# Altium schematic sheet DASH.SchDoc (continued)

## Library data of the parts
C1 C3 C200 = CONDENSADOR 100nF: CAP TANT 100nF 20V 10% 1206; Manufacturer 1: Kyocera AVX; Capacitance: 1uF; Dielectric Material: Tantalum; Dissipation Factor: 4%; ESR (Equivalent Series Resistance): 9R; Leakage Current: 500nA; Polarity: Polar; Tolerance: 20%; Case/Package: 1206; Case Code (Imperial): 1206; Case Code (Metric): 3216; Category: condensador; Component Kind: Standard; ComponentLink1Description: https://datasheet.ciiva.com/12883/taj-27497-12883280.pdf?src-supplier=Mouser; ComponentLink1URL: https://datasheet.ciiva.com/12883/taj-27497-12883280.pdf?src-supplier=Mouser; ComponentLink2Description: https://datasheet.ciiva.com/11794/0900766b80ccdc61-11794382.pdf?src-supplier=RSComponents; ComponentLink2URL: https://datasheet.ciiva.com/11794/0900766b80ccdc61-11794382.pdf?src-supplier=RSComponents; ComponentLink3Description: https://datasheet.ciiva.com/263/taj-263412.pdf?src-supplier=Digi-Key; ComponentLink3URL: https://datasheet.ciiva.com/263/taj-263412.pdf?src-supplier=Digi-Key; ComponentLink4Description: https://datasheet.ciiva.com/3004/taj-3004372.pdf?src-supplier=Avnet; ComponentLink4URL: https://datasheet.ciiva.com/3004/taj-3004372.pdf?src-supplier=Avnet; ComponentLink5Description: https://datasheet.ciiva.com/263/taj-263414.pdf?src-supplier=Digi-Key; ComponentLink5URL: https://datasheet.ciiva.com/263/taj-263414.pdf?src-supplier=Digi-Key; ComponentLink6Description: https://datasheet.ciiva.com/263/taj-263413.pdf?src-supplier=Digi-Key; ComponentLink6URL: https://datasheet.ciiva.com/263/taj-263413.pdf?src-supplier=Digi-Key; ComponentLink7Description: https://datasheet.ciiva.com/3004/taj-3004373.pdf?src-supplier=Avnet; ComponentLink7URL: https://datasheet.ciiva.com/3004/taj-3004373.pdf?src-supplier=Avnet; ComponentLink8Description: https://datasheet.ciiva.com/14608/70001050-14608053.pdf?src-supplier=Allied+Electronics; ComponentLink8URL: https://datasheet.ciiva.com/14608/70001050-14608053.pdf?src-supplier=Allied+Electronics; ComponentLink9Description: https://datasheet.ciiva.com/14598/70001050-14598921.pdf?src-supplier=Allied+Electronics; ComponentLink9URL: https://datasheet.ciiva.com/14598/70001050-14598921.pdf?src-supplier=Allied+Electronics; ComponentLink10Description: https://datasheet.ciiva.com/16486/taj-16486073.pdf?src-supplier=Avnet+Asia; ComponentLink10URL: https://datasheet.ciiva.com/16486/taj-16486073.pdf?src-supplier=Avnet+Asia; ComponentLink11Description: https://datasheet.ciiva.com/16203/taj-16203563.pdf?src-supplier=Future+Electronics; ComponentLink11URL: https://datasheet.ciiva.com/16203/taj-16203563.pdf?src-supplier=Future+Electronics; Depth: 1.6mm; Features: General Purpose; Height: 1.6mm; Height - Seated (Max): 0.071inch; Lead Free: Lead Free; Length: 3.2mm; Library Name: DASH1_Schlib1.SchLib; Manufacturer Part Number 1: TAJA105K020RNJ; Max Operating Temperature: 125°C; Min Operating Temperature: -55°C; Mount: Surface Mount,PCB; Number of Pins: 2; Package Quantity: 1; Packaging: Tape and Reel; Pricing 1: 1=0,31000, 10=0,21900, 100=0,13110, 500=0,09832, 1000=0,08303 (USD); Radiation Hardening: No; REACH SVHC: No SVHC; RoHS: Yes; Stock 1: 73338; Supplier 1: Digi-Key; Supplier Part Number 1: 478-1650-1-ND; Termination: SMD/SMT; Voltage: 20V; Voltage Rating: 20V; Voltage Rating (DC): 20V; Width: 1.6mm
C4 C5 = CONDENSADOR 10uF: CAP TANT 10uF 20V 10% 1206; Manufacturer 1: Kyocera AVX; Capacitance: 1uF; Dielectric Material: Tantalum; Dissipation Factor: 4%; ESR (Equivalent Series Resistance): 9R; Leakage Current: 500nA; Polarity: Polar; Tolerance: 20%; Case/Package: 1206; Case Code (Imperial): 1206; Case Code (Metric): 3216; Category: condensador; Component Kind: Standard; ComponentLink1Description: https://datasheet.ciiva.com/12883/taj-27497-12883280.pdf?src-supplier=Mouser; ComponentLink1URL: https://datasheet.ciiva.com/12883/taj-27497-12883280.pdf?src-supplier=Mouser; ComponentLink2Description: https://datasheet.ciiva.com/11794/0900766b80ccdc61-11794382.pdf?src-supplier=RSComponents; ComponentLink2URL: https://datasheet.ciiva.com/11794/0900766b80ccdc61-11794382.pdf?src-supplier=RSComponents; ComponentLink3Description: https://datasheet.ciiva.com/263/taj-263412.pdf?src-supplier=Digi-Key; ComponentLink3URL: https://datasheet.ciiva.com/263/taj-263412.pdf?src-supplier=Digi-Key; ComponentLink4Description: https://datasheet.ciiva.com/3004/taj-3004372.pdf?src-supplier=Avnet; ComponentLink4URL: https://datasheet.ciiva.com/3004/taj-3004372.pdf?src-supplier=Avnet; ComponentLink5Description: https://datasheet.ciiva.com/263/taj-263414.pdf?src-supplier=Digi-Key; ComponentLink5URL: https://datasheet.ciiva.com/263/taj-263414.pdf?src-supplier=Digi-Key; ComponentLink6Description: https://datasheet.ciiva.com/263/taj-263413.pdf?src-supplier=Digi-Key; ComponentLink6URL: https://datasheet.ciiva.com/263/taj-263413.pdf?src-supplier=Digi-Key; ComponentLink7Description: https://datasheet.ciiva.com/3004/taj-3004373.pdf?src-supplier=Avnet; ComponentLink7URL: https://datasheet.ciiva.com/3004/taj-3004373.pdf?src-supplier=Avnet; ComponentLink8Description: https://datasheet.ciiva.com/14608/70001050-14608053.pdf?src-supplier=Allied+Electronics; ComponentLink8URL: https://datasheet.ciiva.com/14608/70001050-14608053.pdf?src-supplier=Allied+Electronics; ComponentLink9Description: https://datasheet.ciiva.com/14598/70001050-14598921.pdf?src-supplier=Allied+Electronics; ComponentLink9URL: https://datasheet.ciiva.com/14598/70001050-14598921.pdf?src-supplier=Allied+Electronics; ComponentLink10Description: https://datasheet.ciiva.com/16486/taj-16486073.pdf?src-supplier=Avnet+Asia; ComponentLink10URL: https://datasheet.ciiva.com/16486/taj-16486073.pdf?src-supplier=Avnet+Asia; ComponentLink11Description: https://datasheet.ciiva.com/16203/taj-16203563.pdf?src-supplier=Future+Electronics; ComponentLink11URL: https://datasheet.ciiva.com/16203/taj-16203563.pdf?src-supplier=Future+Electronics; Depth: 1.6mm; Features: General Purpose; Height: 1.6mm; Height - Seated (Max): 0.071inch; Lead Free: Lead Free; Length: 3.2mm; Library Name: DASH1_Schlib1.SchLib; Manufacturer Part Number 1: TAJA105K020RNJ; Max Operating Temperature: 125°C; Min Operating Temperature: -55°C; Mount: Surface Mount,PCB; Number of Pins: 2; Package Quantity: 1; Packaging: Tape and Reel; Pricing 1: 1=0,31000, 10=0,21900, 100=0,13110, 500=0,09832, 1000=0,08303 (USD); Radiation Hardening: No; REACH SVHC: No SVHC; RoHS: Yes; Stock 1: 73338; Supplier 1: Digi-Key; Supplier Part Number 1: 478-1650-1-ND; Termination: SMD/SMT; Voltage: 20V; Voltage Rating: 20V; Voltage Rating (DC): 20V; Width: 1.6mm
C6-C14 = CONDENSADOR 1uF: CAP TANT 1uF 20V 10% 1206; Manufacturer 1: Kyocera AVX; Dielectric Material: Tantalum; Dissipation Factor: 4%; ESR (Equivalent Series Resistance): 9R; Leakage Current: 500nA; Polarity: Polar; Tolerance: 20%; Capacitance: 1uF; Case/Package: 1206; Case Code (Imperial): 1206; Case Code (Metric): 3216; Category: condensador; Component Kind: Standard; ComponentLink1Description: https://datasheet.ciiva.com/12883/taj-27497-12883280.pdf?src-supplier=Mouser; ComponentLink1URL: https://datasheet.ciiva.com/12883/taj-27497-12883280.pdf?src-supplier=Mouser; ComponentLink2Description: https://datasheet.ciiva.com/11794/0900766b80ccdc61-11794382.pdf?src-supplier=RSComponents; ComponentLink2URL: https://datasheet.ciiva.com/11794/0900766b80ccdc61-11794382.pdf?src-supplier=RSComponents; ComponentLink3Description: https://datasheet.ciiva.com/263/taj-263412.pdf?src-supplier=Digi-Key; ComponentLink3URL: https://datasheet.ciiva.com/263/taj-263412.pdf?src-supplier=Digi-Key; ComponentLink4Description: https://datasheet.ciiva.com/3004/taj-3004372.pdf?src-supplier=Avnet; ComponentLink4URL: https://datasheet.ciiva.com/3004/taj-3004372.pdf?src-supplier=Avnet; ComponentLink5Description: https://datasheet.ciiva.com/263/taj-263414.pdf?src-supplier=Digi-Key; ComponentLink5URL: https://datasheet.ciiva.com/263/taj-263414.pdf?src-supplier=Digi-Key; ComponentLink6Description: https://datasheet.ciiva.com/263/taj-263413.pdf?src-supplier=Digi-Key; ComponentLink6URL: https://datasheet.ciiva.com/263/taj-263413.pdf?src-supplier=Digi-Key; ComponentLink7Description: https://datasheet.ciiva.com/3004/taj-3004373.pdf?src-supplier=Avnet; ComponentLink7URL: https://datasheet.ciiva.com/3004/taj-3004373.pdf?src-supplier=Avnet; ComponentLink8Description: https://datasheet.ciiva.com/14608/70001050-14608053.pdf?src-supplier=Allied+Electronics; ComponentLink8URL: https://datasheet.ciiva.com/14608/70001050-14608053.pdf?src-supplier=Allied+Electronics; ComponentLink9Description: https://datasheet.ciiva.com/14598/70001050-14598921.pdf?src-supplier=Allied+Electronics; ComponentLink9URL: https://datasheet.ciiva.com/14598/70001050-14598921.pdf?src-supplier=Allied+Electronics; ComponentLink10Description: https://datasheet.ciiva.com/16486/taj-16486073.pdf?src-supplier=Avnet+Asia; ComponentLink10URL: https://datasheet.ciiva.com/16486/taj-16486073.pdf?src-supplier=Avnet+Asia; ComponentLink11Description: https://datasheet.ciiva.com/16203/taj-16203563.pdf?src-supplier=Future+Electronics; ComponentLink11URL: https://datasheet.ciiva.com/16203/taj-16203563.pdf?src-supplier=Future+Electronics; Depth: 1.6mm; Features: General Purpose; Height: 1.6mm; Height - Seated (Max): 0.071inch; Lead Free: Lead Free; Length: 3.2mm; Library Name: DASH1_Schlib1.SchLib; Manufacturer Part Number 1: TAJA105K020RNJ; Max Operating Temperature: 125°C; Min Operating Temperature: -55°C; Mount: Surface Mount,PCB; Number of Pins: 2; Package Quantity: 1; Packaging: Tape and Reel; Pricing 1: 1=0,31000, 10=0,21900, 100=0,13110, 500=0,09832, 1000=0,08303 (USD); Radiation Hardening: No; REACH SVHC: No SVHC; RoHS: Yes; Stock 1: 73338; Supplier 1: Digi-Key; Supplier Part Number 1: 478-1650-1-ND; Termination: SMD/SMT; Voltage: 20V; Voltage Rating: 20V; Voltage Rating (DC): 20V; Width: 1.6mm
C15b C16 = CONDENSADOR 15pF: CAP TANT 15pF 20V 10% 1206; Manufacturer 1: Kyocera AVX; Capacitance: 1uF; Dielectric Material: Tantalum; Dissipation Factor: 4%; ESR (Equivalent Series Resistance): 9R; Leakage Current: 500nA; Polarity: Polar; Tolerance: 20%; Case/Package: 1206; Case Code (Imperial): 1206; Case Code (Metric): 3216; Category: condensador; Component Kind: Standard; ComponentLink1Description: https://datasheet.ciiva.com/12883/taj-27497-12883280.pdf?src-supplier=Mouser; ComponentLink1URL: https://datasheet.ciiva.com/12883/taj-27497-12883280.pdf?src-supplier=Mouser; ComponentLink2Description: https://datasheet.ciiva.com/11794/0900766b80ccdc61-11794382.pdf?src-supplier=RSComponents; ComponentLink2URL: https://datasheet.ciiva.com/11794/0900766b80ccdc61-11794382.pdf?src-supplier=RSComponents; ComponentLink3Description: https://datasheet.ciiva.com/263/taj-263412.pdf?src-supplier=Digi-Key; ComponentLink3URL: https://datasheet.ciiva.com/263/taj-263412.pdf?src-supplier=Digi-Key; ComponentLink4Description: https://datasheet.ciiva.com/3004/taj-3004372.pdf?src-supplier=Avnet; ComponentLink4URL: https://datasheet.ciiva.com/3004/taj-3004372.pdf?src-supplier=Avnet; ComponentLink5Description: https://datasheet.ciiva.com/263/taj-263414.pdf?src-supplier=Digi-Key; ComponentLink5URL: https://datasheet.ciiva.com/263/taj-263414.pdf?src-supplier=Digi-Key; ComponentLink6Description: https://datasheet.ciiva.com/263/taj-263413.pdf?src-supplier=Digi-Key; ComponentLink6URL: https://datasheet.ciiva.com/263/taj-263413.pdf?src-supplier=Digi-Key; ComponentLink7Description: https://datasheet.ciiva.com/3004/taj-3004373.pdf?src-supplier=Avnet; ComponentLink7URL: https://datasheet.ciiva.com/3004/taj-3004373.pdf?src-supplier=Avnet; ComponentLink8Description: https://datasheet.ciiva.com/14608/70001050-14608053.pdf?src-supplier=Allied+Electronics; ComponentLink8URL: https://datasheet.ciiva.com/14608/70001050-14608053.pdf?src-supplier=Allied+Electronics; ComponentLink9Description: https://datasheet.ciiva.com/14598/70001050-14598921.pdf?src-supplier=Allied+Electronics; ComponentLink9URL: https://datasheet.ciiva.com/14598/70001050-14598921.pdf?src-supplier=Allied+Electronics; ComponentLink10Description: https://datasheet.ciiva.com/16486/taj-16486073.pdf?src-supplier=Avnet+Asia; ComponentLink10URL: https://datasheet.ciiva.com/16486/taj-16486073.pdf?src-supplier=Avnet+Asia; ComponentLink11Description: https://datasheet.ciiva.com/16203/taj-16203563.pdf?src-supplier=Future+Electronics; ComponentLink11URL: https://datasheet.ciiva.com/16203/taj-16203563.pdf?src-supplier=Future+Electronics; Depth: 1.6mm; Features: General Purpose; Height: 1.6mm; Height - Seated (Max): 0.071inch; Lead Free: Lead Free; Length: 3.2mm; Library Name: DASH1_Schlib1.SchLib; Manufacturer Part Number 1: TAJA105K020RNJ; Max Operating Temperature: 125°C; Min Operating Temperature: -55°C; Mount: Surface Mount,PCB; Number of Pins: 2; Package Quantity: 1; Packaging: Tape and Reel; Pricing 1: 1=0,31000, 10=0,21900, 100=0,13110, 500=0,09832, 1000=0,08303 (USD); Radiation Hardening: No; REACH SVHC: No SVHC; RoHS: Yes; Stock 1: 73338; Supplier 1: Digi-Key; Supplier Part Number 1: 478-1650-1-ND; Termination: SMD/SMT; Voltage: 20V; Voltage Rating: 20V; Voltage Rating (DC): 20V; Width: 1.6mm
D D15-D55 = LED_SEG: LED GREEN CLEAR 2SMD 528NM; Manufacturer 1: Wurth Electronics; Component Kind: Standard; ComponentLink1Description: https://katalog.we-online.de/led/datasheet/150224GS73100.pdf?src-supplier=Digi-Key; ComponentLink1URL: https://katalog.we-online.de/led/datasheet/150224GS73100.pdf?src-supplier=Digi-Key; Library Name: DASH1_Schlib1.SchLib; Manufacturer Part Number 1: 150224GS73100; Pricing 1: 1=0,71000, 50=0,58400, 100=0,41800, 500=0,38100 (USD); RoHS: No; Stock 1: 697; Supplier 1: Digi-Key; Supplier Part Number 1: 732-11398-1-ND
D1 D2 = DIODE: TVS DIODE 12V 19.9V DO214AC; Manufacturer 1: Littelfuse; Breakdown Voltage: 13.3V; Capacitance: 800pF; Direction: Bidirectional; Element Configuration: Single; Leakage Current: 1uA; Max Breakdown Voltage: 14.7V; Max Output Current: 20.1A; Max Power Dissipation: 3.3W; Number of Bidirectional Channels: 1; Peak Pulse Power: 400W; Power Line Protection: No; Test Current: 1mA; Case/Package: SMA; Category: Diode; Clamping Voltage: 19.9V; Component Kind: Standard; ComponentLink1Description: https://datasheet.ciiva.com/14162/smaj-eng-ds-14162034.pdf?src-supplier=Distrelec; ComponentLink1URL: https://datasheet.ciiva.com/14162/smaj-eng-ds-14162034.pdf?src-supplier=Distrelec; ComponentLink2Description: https://datasheet.ciiva.com/25624/0900766b810f4edb-25624831.pdf?src-supplier=RSComponents; ComponentLink2URL: https://datasheet.ciiva.com/25624/0900766b810f4edb-25624831.pdf?src-supplier=RSComponents; ComponentLink3Description: https://datasheet.ciiva.com/331/littelfuse-tvs-diode-smaj-datasheet-pdf-331080.pdf?src-supplier=Digi-Key; ComponentLink3URL: https://datasheet.ciiva.com/331/littelfuse-tvs-diode-smaj-datasheet-pdf-331080.pdf?src-supplier=Digi-Key; ComponentLink4Description: https://datasheet.ciiva.com/8062/littelfuse-tvs-diode-smaj-8062265.pdf?src-supplier=Element14; ComponentLink4URL: https://datasheet.ciiva.com/8062/littelfuse-tvs-diode-smaj-8062265.pdf?src-supplier=Element14; ComponentLink5Description: https://datasheet.ciiva.com/4511/littelfuse-tvs-diode-smaj-23503-4511037.pdf?src-supplier=Mouser; ComponentLink5URL: https://datasheet.ciiva.com/4511/littelfuse-tvs-diode-smaj-23503-4511037.pdf?src-supplier=Mouser; ComponentLink6Description: https://datasheet.ciiva.com/28473/607617-28473381.pdf?src-supplier=Element14; ComponentLink6URL: https://datasheet.ciiva.com/28473/607617-28473381.pdf?src-supplier=Element14; ComponentLink7Description: https://datasheet.ciiva.com/331/littelfuse-tvs-diode-smaj-datasheet-pdf-331078.pdf?src-supplier=Digi-Key; ComponentLink7URL: https://datasheet.ciiva.com/331/littelfuse-tvs-diode-smaj-datasheet-pdf-331078.pdf?src-supplier=Digi-Key; ComponentLink8Description: https://datasheet.ciiva.com/331/littelfuse-tvs-diode-smaj-datasheet-pdf-331079.pdf?src-supplier=Digi-Key; ComponentLink8URL: https://datasheet.ciiva.com/331/littelfuse-tvs-diode-smaj-datasheet-pdf-331079.pdf?src-supplier=Digi-Key; Composition: Zener; Height: 2.087mm; Lead Free: Lead Free; Length: 4.5mm; Library Name: DASH1_Schlib1.SchLib; Manufacturer Part Number 1: SMAJ12CA; Max Operating Temperature: 150°C; Max Reverse Leakage Current: 1uA; Max Surge Current: 20.1A; Min Breakdown Voltage: 13.3V; Min Operating Temperature: -65°C; Mount: Surface Mount; Number of Channels: 1; Number of Elements: 1; Number of Pins: 2; Operating Supply Voltage: 12V; Package Quantity: 5000; Packaging: Tape and Reel; Peak Pulse Current: 20.1A; Polarity: Bidirectional; Power Dissipation: 400W; Pricing 1: 1=0,45000, 10=0,33900, 25=0,30520, 100=0,16100, 250=0,15928, 500=0,14264, 1000=0,11100, 2500=0,10156 (USD); Radiation Hardening: No; REACH SVHC: No SVHC; Reverse Breakdown Voltage: 13.3V; Reverse Standoff Voltage: 12V; RoHS: Yes; Stock 1: 18116; Supplier 1: Digi-Key; Supplier Part Number 1: SMAJ12CALFCT-ND; Termination: SMD/SMT; Voltage Rating (DC): 12V; Width: 2.79mm
D3-D14 = LED: WURTH ELEKTRONIK   150141GS73100   LED, 1411, GREEN, 1000MCD, 525NM; Manufacturer 1: Wurth Electronics; Forward Current: 30mA; Forward Voltage: 3.1V; Lens Style: Clear,Round; Test Current: 20mA; Viewing Angle: 120°; Case/Package: 3528; Case Code (Imperial): 1411; Case Code (Metric): 3528; Color: Green; Component Kind: Standard; ComponentLink1Description: https://datasheet.ciiva.com/23843/150141gs73100-23843266.pdf?src-supplier=Newark; ComponentLink1URL: https://datasheet.ciiva.com/23843/150141gs73100-23843266.pdf?src-supplier=Newark; ComponentLink2Description: https://datasheet.ciiva.com/29278/759463-da-01-en-smd-topled-einf-gruen-150141gs73100-29278953.pdf?src-supplier=Conrad; ComponentLink2URL: https://datasheet.ciiva.com/29278/759463-da-01-en-smd-topled-einf-gruen-150141gs73100-29278953.pdf?src-supplier=Conrad; ComponentLink3Description: https://datasheet.ciiva.com/22656/1911484-22656997.pdf?src-supplier=Element14; ComponentLink3URL: https://datasheet.ciiva.com/22656/1911484-22656997.pdf?src-supplier=Element14; ComponentLink4Description: https://datasheet.ciiva.com/29563/150141gs73100-29563029.pdf?src-supplier=Digi-Key; ComponentLink4URL: https://datasheet.ciiva.com/29563/150141gs73100-29563029.pdf?src-supplier=Digi-Key; ComponentLink5Description: https://datasheet.ciiva.com/29563/150141gs73100-29563030.pdf?src-supplier=Digi-Key; ComponentLink5URL: https://datasheet.ciiva.com/29563/150141gs73100-29563030.pdf?src-supplier=Digi-Key; ComponentLink6Description: https://datasheet.ciiva.com/48430/150141gs73100-eng-tds-48430060.pdf?src-supplier=Distrelec; ComponentLink6URL: https://datasheet.ciiva.com/48430/150141gs73100-eng-tds-48430060.pdf?src-supplier=Distrelec; Dominant Wavelength: 525nm; Height: 1.9mm; Lead Free: Lead Free; Length: 3.5mm; Library Name: DASH1_Schlib1.SchLib; Luminous Intensity: 1000mcd; Manufacturer Part Number 1: 150141GS73100; Max Operating Temperature: 85°C; Min Operating Temperature: -40°C; Mount: Surface Mount; Package Quantity: 2000; Packaging: Cut Tape; Pricing 1: 1=0,18000, 50=0,17600, 100=0,15300, 500=0,11700 (USD); REACH SVHC: Unknown; RoHS: Yes; Stock 1: 10639; Supplier 1: Digi-Key; Supplier Part Number 1: 732-4997-1-ND; Wavelength: 525nm; Width: 2.8mm
DISPLAY = DISPLAY: 2.54mm (0.1") Pitch SIL Vertical PC Tail Socket Assembly, selective gold + tin, 4 contacts; Manufacturer 1: Harwin; Connector Type: Receptacle,Socket; Contact Resistance: 20mR; Gender: Female; Max Current Rating: 3A; Number of Contacts: 4; Case/Package: SIL; Color: Black; Component Kind: Standard; ComponentLink1Description: DATASHEET; ComponentLink1URL: https://eu.mouser.com/datasheet/2/127/dogl128-6e-3984.pdf; ComponentLink2Description: WHERE BUY IT; ComponentLink2URL: https://eu.mouser.com/productdetail/electronic-assembly/ea-led68x51-w?qs=7%252buJNxjmPmV34T7aeje2sQ==; Contact Gender: Female; Contact Material: Bronze; Contact Plating: Gold,Tin; Depth: 2.5mm; Housing Color: Black; Housing Material: Nylon; Length: 10.56mm; Library Name: DASH1_Schlib1.SchLib; Manufacturer Part Number 1: M20-7820442; Max Operating Temperature: 105°C; Min Operating Temperature: -40°C; Mount: Through Hole; Number of Positions: 4; Number of Rows: 1; Orientation: Straight; Package Quantity: 56; Packaging: Rail/Tube; Pitch: 2.54mm; Pricing 1: 1=0,81000, 10=0,71400, 100=0,61600, 500=0,50400, 1000=0,44800, 5000=0,39200, 10000=0,37240 (USD); Radiation Hardening: No; RoHS: Yes; Stock 1: 903; Supplier 1: Digi-Key; Supplier Part Number 1: 952-1791-ND; Termination: Solder; Weight: 0.012699oz
J2 J3 J8 = HEADER: CONN HEADER VERT 2POS 2.54MM; Manufacturer 1: Amphenol FCI; Connector Type: Male Pin; Contact Post Length: 3.05mm; Current Rating: 3A; Gender: Male; Insulation Resistance: 5GR; Max Voltage Rating (AC): 1.5kV; Number of Contacts: 2; Category: Connector; Color: Black; Component Kind: Standard; ComponentLink1Description: https://datasheet.ciiva.com/5155/68000-274330-5155060.pdf?src-supplier=Mouser; ComponentLink1URL: https://datasheet.ciiva.com/5155/68000-274330-5155060.pdf?src-supplier=Mouser; ComponentLink2Description: https://datasheet.ciiva.com/16726/68000-16726182.pdf?src-supplier=Avnet+Europe; ComponentLink2URL: https://datasheet.ciiva.com/16726/68000-16726182.pdf?src-supplier=Avnet+Europe; ComponentLink3Description: https://datasheet.ciiva.com/10149/68000-10149336.pdf?src-supplier=Avnet; ComponentLink3URL: https://datasheet.ciiva.com/10149/68000-10149336.pdf?src-supplier=Avnet; ComponentLink4Description: https://datasheet.ciiva.com/731/68000-731070.pdf?src-supplier=Digi-Key; ComponentLink4URL: https://datasheet.ciiva.com/731/68000-731070.pdf?src-supplier=Digi-Key; Contact Gender: Male; Contact Material: Bronze; Contact Mating Length: 0.23inch; Contact Plating: Gold,GXT; Depth: 2.41mm; Flammability Rating: UL94 V-0; Housing Color: Black; Housing Material: Thermoplastic; Length: 5.08mm; Library Name: DASH1_Schlib1.SchLib; Manufacturer Part Number 1: 68001-202HLF; Max Operating Temperature: 125°C; Min Operating Temperature: -65°C; Mount: Through Hole; Number of Positions: 2; Number of Rows: 1; Orientation: Straight; Package Quantity: 100; Packaging: Bulk; Pitch: 2.54mm; Pricing 1: 1=0,24000, 10=0,22100, 100=0,15290, 500=0,12936, 1000=0,10584, 5000=0,09408, 10000=0,08526 (USD); Radiation Hardening: No; REACH SVHC: No SVHC; RoHS: Yes; Stock 1: 39971; Supplier 1: Digi-Key; Supplier Part Number 1: 609-3469-ND; Termination: Solder; Voltage Rating: 1.5kV
J4 = HEADER_J4: CONN HEADER R/A 2POS; Manufacturer 1: Molex; Approvals: UL,CSA,TUV; Contact Resistance: 10mR; Current Rating: 5A; Gender: Male; Glow Wire Compliant: Compliant; Insulation Resistance: 1GR; Max Voltage Rating (DC): 250.25kV; Number of Circuits: 2; Pitch: 3mm; Voltage Rating: 600V; Voltage Rating (DC): 250V; Case/Package: SMC; Color: Black; Component Kind: Standard; ComponentLink1Description: https://datasheet.ciiva.com/14155/04402310-14155203.pdf?src-supplier=Distrelec; ComponentLink1URL: https://datasheet.ciiva.com/14155/04402310-14155203.pdf?src-supplier=Distrelec; ComponentLink2Description: https://datasheet.ciiva.com/19454/883270-19454006.pdf?src-supplier=Jameco; ComponentLink2URL: https://datasheet.ciiva.com/19454/883270-19454006.pdf?src-supplier=Jameco; ComponentLink3Description: https://datasheet.ciiva.com/5150/0430450200-pcb-headers-145770-5150407.pdf?src-supplier=Mouser; ComponentLink3URL: https://datasheet.ciiva.com/5150/0430450200-pcb-headers-145770-5150407.pdf?src-supplier=Mouser; ComponentLink4Description: https://datasheet.ciiva.com/11747/0900766b80027348-11747001.pdf?src-supplier=RSComponents; ComponentLink4URL: https://datasheet.ciiva.com/11747/0900766b80027348-11747001.pdf?src-supplier=RSComponents; ComponentLink5Description: https://datasheet.ciiva.com/16656/0430450200-pcb-headers-16656330.pdf?src-supplier=Avnet+Europe; ComponentLink5URL: https://datasheet.ciiva.com/16656/0430450200-pcb-headers-16656330.pdf?src-supplier=Avnet+Europe; ComponentLink6Description: https://datasheet.ciiva.com/10090/0430450200-pcb-headers-10090299.pdf?src-supplier=Avnet; ComponentLink6URL: https://datasheet.ciiva.com/10090/0430450200-pcb-headers-10090299.pdf?src-supplier=Avnet; ComponentLink7Description: https://datasheet.ciiva.com/36854/124280430450200-pcb-headers-36854331.pdf?src-supplier=Onlinecomponents.com; ComponentLink7URL: https://datasheet.ciiva.com/36854/124280430450200-pcb-headers-36854331.pdf?src-supplier=Onlinecomponents.com; ComponentLink8Description: https://datasheet.ciiva.com/1443/1859921-1443437.pdf?src-supplier=Element14; ComponentLink8URL: https://datasheet.ciiva.com/1443/1859921-1443437.pdf?src-supplier=Element14; Contact Gender: Male; Contact Material: Brass,Tin; Contact Plating: Tin; Diameter: 3mm; Flammability Rating: UL94 V-0; Halogen Free: Low Halogen; Housing Color: Black; Housing Material: Thermoplastic; Lead Free: Lead Free; Library Name: DASH1_Schlib1.SchLib; Manufacturer Part Number 1: 43045-0200; Material: Thermoplastic; Max Operating Temperature: 105°C; Min Operating Temperature: -40°C; Mount: Through Hole; Number of Contacts: 2; Number of Positions: 2; Number of Rows: 2; Orientation: Right Angle; Package Quantity: 3168; Packaging: Tray; Pricing 1: 1=0,97000, 10=0,84900, 100=0,73280, 500=0,59958, 1000=0,53296, 5000=0,46634, 10000=0,44302 (USD); Radiation Hardening: No; REACH SVHC: Unknown; RoHS: Yes; Row Spacing: 3mm; Stock 1: 6359; Supplier 1: Digi-Key; Supplier Part Number 1: WM1813-ND; Termination: Through Hole
J5 = HEADER_J5: NANO-FIT RIGHT ANGLE HEADER, TH; Manufacturer 1: Molex; Connector Type: Header,Male Pin; Number of Positions: 4; Component Kind: Standard; ComponentLink1Description: https://www.molex.com/pdm_docs/ps/PS-105300-100-001.pdf?src-supplier=Digi-Key; ComponentLink1URL: https://www.molex.com/pdm_docs/ps/PS-105300-100-001.pdf?src-supplier=Digi-Key; Contact Material: Brass; Contact Plating: Tin; Contact Shape: Square; Fastening Type: Latch; Insulation Color: Black; Insulation Material: Polymer; Library Name: DASH1_Schlib1.SchLib; Manufacturer Part Number 1: 1053141104; Max Operating Temperature: 105°C; Min Operating Temperature: -40°C; Mount: Through Hole; Number of Rows: 2; Packaging: Tray; Pricing 1: 1=1,08000, 10=0,95100, 300=0,74580, 600=0,67122, 1200=0,59664, 5100=0,52206, 10200=0,49596 (USD); RoHS: No; Stock 1: 1022; Supplier 1: Digi-Key; Supplier Part Number 1: WM16907-ND; Termination: Solder
J6 = HEADER_J6: CONN HEADER R/A 8POS 3MM; Manufacturer 1: Molex; Approvals: UL,CSA,TUV; Contact Resistance: 10mR; Current Rating: 5A; Gender: Male; Glow Wire Compliant: Compliant; Insulation Resistance: 1GR; Max Voltage Rating (DC): 250.25kV; Number of Circuits: 8; Voltage Rating: 600V; Voltage Rating (AC): 250V; Case/Package: SMC; Color: Black; Component Kind: Standard; ComponentLink1Description: https://datasheet.ciiva.com/5158/0430450800-pcb-headers-142625-5158817.pdf?src-supplier=Mouser; ComponentLink1URL: https://datasheet.ciiva.com/5158/0430450800-pcb-headers-142625-5158817.pdf?src-supplier=Mouser; ComponentLink2Description: https://datasheet.ciiva.com/7683/27645-7683683.pdf?src-supplier=Element14; ComponentLink2URL: https://datasheet.ciiva.com/7683/27645-7683683.pdf?src-supplier=Element14; ComponentLink3Description: https://datasheet.ciiva.com/19462/883536-19462164.pdf?src-supplier=Jameco; ComponentLink3URL: https://datasheet.ciiva.com/19462/883536-19462164.pdf?src-supplier=Jameco; ComponentLink4Description: https://datasheet.ciiva.com/11751/0900766b80027348-11751142.pdf?src-supplier=RSComponents; ComponentLink4URL: https://datasheet.ciiva.com/11751/0900766b80027348-11751142.pdf?src-supplier=RSComponents; ComponentLink5Description: https://datasheet.ciiva.com/30198/267770430450800-pcb-headers-30198875.pdf?src-supplier=Onlinecomponents.com; ComponentLink5URL: https://datasheet.ciiva.com/30198/267770430450800-pcb-headers-30198875.pdf?src-supplier=Onlinecomponents.com; ComponentLink6Description: https://datasheet.ciiva.com/1443/1850016-1443537.pdf?src-supplier=Element14; ComponentLink6URL: https://datasheet.ciiva.com/1443/1850016-1443537.pdf?src-supplier=Element14; ComponentLink7Description: https://datasheet.ciiva.com/14155/04402310-14155206.pdf?src-supplier=Distrelec; ComponentLink7URL: https://datasheet.ciiva.com/14155/04402310-14155206.pdf?src-supplier=Distrelec; ComponentLink8Description: https://datasheet.ciiva.com/16657/0430450800-pcb-headers-16657964.pdf?src-supplier=Avnet+Europe; ComponentLink8URL: https://datasheet.ciiva.com/16657/0430450800-pcb-headers-16657964.pdf?src-supplier=Avnet+Europe; ComponentLink9Description: https://datasheet.ciiva.com/10087/0430450800-pcb-headers-10087853.pdf?src-supplier=Avnet; ComponentLink9URL: https://datasheet.ciiva.com/10087/0430450800-pcb-headers-10087853.pdf?src-supplier=Avnet; Contact Material: Brass,Tin; Contact Plating: Tin; Depth: 12.2mm; Diameter: 3mm; Flammability Rating: UL94 V-0; Halogen Free: Low Halogen; Height: 8.77mm; Housing Color: Black; Housing Material: Thermoplastic; Lead Free: Lead Free; Length: 16.15mm; Library Name: DASH1_Schlib1.SchLib; Manufacturer Part Number 1: 43045-0800; Material: Polyester; Max Current Rating: 5A; Max Operating Temperature: 105°C; Min Operating Temperature: -40°C; Mount: Through Hole; Number of Contacts: 8; Number of Poles: 8; Number of Positions: 8; Number of Rows: 2; Orientation: Right Angle; Package Quantity: 1440; Packaging: Tray; Pitch: 3mm; Pricing 1: 1=1,91000, 10=1,73100, 100=1,48390, 500=1,23656, 1000=1,05990, 5000=0,93978, 10000=0,91858 (USD); Radiation Hardening: No; REACH SVHC: Unknown; RoHS: Yes; Row Spacing: 3mm; Stock 1: 1548; Supplier 1: Digi-Key; Supplier Part Number 1: WM1816-ND; Termination: Solder; Voltage Rating (DC): 250V; Working Voltage: 250V
J7 = HEADER_J7: Conn Power HDR 8 POS 2.5mm Solder RA Thru-Hole 8 Terminal 1 Port Nano-Fit Tray; Manufacturer 1: Molex; Connector Type: Header,Male Pin; Number of Positions: 8; Component Kind: Standard; ComponentLink1Description: Datasheet; ComponentLink1URL: https://www.molex.com/pdm_docs/sd/1053141108_sd.pdf?src-supplier=Digi-Key; ComponentLink2Description: INFO; ComponentLink2URL: https://www.molex.com/molex/products/part-detail/pcb_headers/1053141108; Contact Material: Brass; Contact Plating: Tin; Contact Shape: Square; Fastening Type: Latch; Insulation Color: Black; Insulation Material: Polymer; Library Name: DASH1_Schlib1.SchLib; Manufacturer Part Number 1: 105314-1108; Max Operating Temperature: 105°C; Min Operating Temperature: -40°C; Mount: Through Hole; Number of Rows: 2; Packaging: Tray; Pricing 1: 1=1,71000, 10=1,55200, 156=1,33013, 624=1,10845, 1092=0,95010, 5148=0,84242, 10140=0,82342 (USD); RoHS: No; Stock 1: 846; Supplier 1: Digi-Key; Supplier Part Number 1: WM16909-ND; Termination: Solder
J9 = HEADER_J9: CONN HEADER R/A 2POS 2.5MM; Manufacturer 1: Molex; Gender: Male; Color: Black; Component Kind: Standard; ComponentLink1Description: http://www.farnell.com/datasheets/2221462.pdf?src-supplier=Farnell; ComponentLink1URL: http://www.farnell.com/datasheets/2221462.pdf?src-supplier=Farnell; ComponentLink2Description: http://www.farnell.com/datasheets/2059602.pdf?src-supplier=Element14; ComponentLink2URL: http://www.farnell.com/datasheets/2059602.pdf?src-supplier=Element14; ComponentLink3Description: http://www.farnell.com/datasheets/2221462.pdf?src-supplier=Newark; ComponentLink3URL: http://www.farnell.com/datasheets/2221462.pdf?src-supplier=Newark; ComponentLink4Description: https://www.molex.com/pdm_docs/sd/1053131102_sd.pdf?src-supplier=Digi-Key; ComponentLink4URL: https://www.molex.com/pdm_docs/sd/1053131102_sd.pdf?src-supplier=Digi-Key; Contact Material: Brass; Contact Plating: Gold; Library Name: DASH1_Schlib1.SchLib; Manufacturer Part Number 1: 105313-1202; Mount: Through Hole; Number of Pins: 2; Number of Rows: 1; Pricing 1: 10=0,81300, 50=0,47400, 200=0,46200, 500=0,45000 (EUR); REACH SVHC: Unknown; RoHS: No; Stock 1: 130; Supplier 1: RSComponents; Supplier Part Number 1: 1225083; Termination: Through Hole
PIC18LF4685-I/PT = PIC18LF4685-I/PT: IC MCU 8BIT 96KB FLASH 44TQFP; Manufacturer 1: Microchip; Access Time: 40us; Core Architecture: PIC; Data Bus Width: 8b; Frequency: 40MHz; Interface: I2C,SPI,CAN,UART,USART; Max Power Dissipation: 1W; Max Supply Voltage: 5.5V; Min Supply Voltage: 2V; Number of ADC Channels: 11; Number of I2C Channels: 1; Number of I/Os: 36; Number of Programmable I/O: 44; Number of Timers/Counters: 4; Oscillator Type: Internal; Peripherals: PWM,I2C,Brown-out Detect/Reset,POR,WDT,HLVD; RAM Size: 3.25KB; Watchdog Timer: Yes; Case/Package: TQFP; Component Kind: Standard; ComponentLink1Description: DATASHEET; ComponentLink1URL: https://datasheet.ciiva.com/9230/jp026433-9230074.pdf?src-supplier=Avnet; Height: 1.05mm; Lead Free: Lead Free; Length: 10mm; Library Name: DASH1_Schlib1.SchLib; Manufacturer Part Number 1: PIC18LF4685-I/PT; Max Frequency: 40MHz; Max Operating Temperature: 85°C; Memory Size: 96KB; Memory Type: FLASH; Min Operating Temperature: -40°C; Mount: Surface Mount; Number of Pins: 44; Number of SPI Channels: 1; Number of UART Channels: 1; Package Quantity: 160; Packaging: Tray; Pricing 1: 1=8,79000, 25=8,06000 (USD); Radiation Hardening: No; REACH SVHC: No SVHC; RoHS: Yes; Stock 1: 53; Supplier 1: Digi-Key; Supplier Part Number 1: PIC18LF4685-I/PT-ND; Width: 10mm
Pickit_3 = HEADER_J1: Conn Unshrouded Header HDR 5 POS 2.54mm Solder RA Thru-Hole; Manufacturer 1: Samtec; Manufacturer Part Number 1: TLW-105-01-G-S-RA; Gender: Male; Number of Contacts: 5; Component Kind: Standard; ComponentLink1Description: https://datasheet.ciiva.com/10350/mtlw-th-10350142.pdf?src-supplier=Avnet; ComponentLink1URL: https://datasheet.ciiva.com/10350/mtlw-th-10350142.pdf?src-supplier=Avnet; Contact Plating: Gold; Library Name: DASH1_Schlib1.SchLib; Mount: Through Hole; Number of Rows: 1; Packaging: Bulk; Pitch: 2.54mm; Pricing 1: 1=1,20000 (USD); Radiation Hardening: No; RoHS: Yes; Stock 1: 950; Supplier 1: Digi-Key; Supplier Part Number 1: TLW-105-01-G-S-RA-ND; Termination: Solder
R R20-R31 R42 R58 R59 R61-R63 R71-R77 R79 R82 R83 R200 R201 = RESISTOR 150R: RES SMD 150 OHM 5% 1/4W 1206; Manufacturer 1: Panasonic; Max Power Dissipation: 250mW; Resistance: 150R; Temperature Coefficient: 200ppm/°C; Voltage Rating: 200V; Case/Package: 1206; Case Code (Imperial): 1206; Case Code (Metric): 3216; Component Kind: Standard; ComponentLink1Description: https://datasheet.ciiva.com/34303/1990341-34303679.pdf?src-supplier=Jameco; ComponentLink1URL: https://datasheet.ciiva.com/34303/1990341-34303679.pdf?src-supplier=Jameco; ComponentLink2Description: https://datasheet.ciiva.com/30146/4aoa0000ce1-30146493.pdf?src-supplier=Onlinecomponents.com; ComponentLink2URL: https://datasheet.ciiva.com/30146/4aoa0000ce1-30146493.pdf?src-supplier=Onlinecomponents.com; ComponentLink3Description: https://datasheet.ciiva.com/16771/70255313-16771855.pdf?src-supplier=Allied+Electronics; ComponentLink3URL: https://datasheet.ciiva.com/16771/70255313-16771855.pdf?src-supplier=Allied+Electronics; ComponentLink4Description: https://datasheet.ciiva.com/16773/70255313-16773382.pdf?src-supplier=Allied+Electronics; ComponentLink4URL: https://datasheet.ciiva.com/16773/70255313-16773382.pdf?src-supplier=Allied+Electronics; ComponentLink5Description: https://datasheet.ciiva.com/6082/1500035-6082279.pdf?src-supplier=Farnell; ComponentLink5URL: https://datasheet.ciiva.com/6082/1500035-6082279.pdf?src-supplier=Farnell; ComponentLink6Description: https://datasheet.ciiva.com/17207/aoa0000ce1-17207400.pdf?src-supplier=Avnet+Europe; ComponentLink6URL: https://datasheet.ciiva.com/17207/aoa0000ce1-17207400.pdf?src-supplier=Avnet+Europe; ComponentLink7Description: https://datasheet.ciiva.com/46377/1500035-46377050.pdf?src-supplier=Element14; ComponentLink7URL: https://datasheet.ciiva.com/46377/1500035-46377050.pdf?src-supplier=Element14; ComponentLink8Description: https://datasheet.ciiva.com/9185/aoa0000ce1-9185516.pdf?src-supplier=Avnet; ComponentLink8URL: https://datasheet.ciiva.com/9185/aoa0000ce1-9185516.pdf?src-supplier=Avnet; ComponentLink9Description: https://datasheet.ciiva.com/16513/aoa0000ce1-16513711.pdf?src-supplier=Avnet+Asia; ComponentLink9URL: https://datasheet.ciiva.com/16513/aoa0000ce1-16513711.pdf?src-supplier=Avnet+Asia; Composition: Thick Film; Contact Plating: Tin; Depth: 1.6mm; Features: Automotive AEC-Q200; Height: 0.6mm; Lead Free: Lead Free; Length: 3.2mm; Library Name: DASH1_Schlib1.SchLib; Manufacturer Part Number 1: ERJ-8GEYJ151V; Max Operating Temperature: 155°C; Military Standard: Not; Min Operating Temperature: -55°C; Mount: Surface Mount; Number of Terminations: 2; Package Quantity: 1; Packaging: Tape and Reel; Power Rating: 250mW; Pricing 1: 1=0,10000, 10=0,06500, 100=0,02660, 1000=0,01195, 2500=0,01037 (USD); Radiation Hardening: No; REACH SVHC: No SVHC; RoHS: Yes; Stock 1: 112821; Supplier 1: Digi-Key; Supplier Part Number 1: P150ECT-ND; Termination: SMD/SMT; Tolerance: 5%; Voltage Rating (DC): 200V; Weight: 0.000571oz; Width: 1.6mm
R1-R7 R70 R78 = RESISTOR 10K: RES SMD 10K OHM 5% 1/4W 1206; Manufacturer 1: Vishay Dale; Max Power Dissipation: 250mW; Resistance: 10kR; Temperature Coefficient: 200ppm/°C; Voltage Rating: 200V; Case/Package: 1206; Case Code (Imperial): 1206; Case Code (Metric): 3216; Component Kind: Standard; ComponentLink1Description: https://datasheet.ciiva.com/2567/dcrcw-2567803.pdf?src-supplier=Digi-Key; ComponentLink1URL: https://datasheet.ciiva.com/2567/dcrcw-2567803.pdf?src-supplier=Digi-Key; ComponentLink2Description: https://datasheet.ciiva.com/9052/dcrcw-9052558.pdf?src-supplier=Avnet; ComponentLink2URL: https://datasheet.ciiva.com/9052/dcrcw-9052558.pdf?src-supplier=Avnet; Composition: Thick Film; Contact Plating: Tin,Lead; Depth: 1.6mm; Features: Automotive AEC-Q200; Height: 0.024inch; Lead Free: Contains Lead; Length: 3.2mm; Library Name: DASH1_Schlib1.SchLib; Manufacturer Part Number 1: CRCW120610K0JNTA; Max Operating Temperature: 155°C; Military Standard: Not; Min Operating Temperature: -55°C; Number of Terminations: 2; Package Quantity: 5000; Packaging: Tape and Reel; Power Dissipation: 250mW; Power Rating: 250mW; Pricing 1: 5000=0,02620, 10000=0,02542, 20000=0,02439, 30000=0,02372, 50000=0,02308 (USD); Radiation Hardening: No; RoHS: Yes; Stock 1: 5000; Supplier 1: Avnet; Supplier Part Number 1: CRCW120610K0JNTA; Termination: SMD/SMT; Tolerance: 5%; Voltage Rating (DC): 200V; Weight: 0.000353oz; Width: 0.063inch
R12 R32-R41 R43-R57 R60 R80 R81 = RESISTOR 1K: Res Thick Film 1206(3216) 1K Ohm 5% 1/4W 200ppm/C Molded SMD Punched Carrier T/R; Manufacturer 1: Panasonic; Categoría: Resistencia; Max Power Dissipation: 250mW; Resistance: 1kR; Temperature Coefficient: 200ppm/°C; Voltage Rating: 200V; Case/Package: 1206; Case Code (Imperial): 1206; Case Code (Metric): 3216; Component Kind: Standard; ComponentLink1Description: https://datasheet.ciiva.com/24069/aoa0000ce1-37422-24069653.pdf?src-supplier=Mouser; ComponentLink1URL: https://datasheet.ciiva.com/24069/aoa0000ce1-37422-24069653.pdf?src-supplier=Mouser; ComponentLink2Description: https://datasheet.ciiva.com/30141/4aoa0000ce1-30141014.pdf?src-supplier=Onlinecomponents.com; ComponentLink2URL: https://datasheet.ciiva.com/30141/4aoa0000ce1-30141014.pdf?src-supplier=Onlinecomponents.com; ComponentLink3Description: https://datasheet.ciiva.com/43460/1500035-43460230.pdf?src-supplier=Farnell; ComponentLink3URL: https://datasheet.ciiva.com/43460/1500035-43460230.pdf?src-supplier=Farnell; ComponentLink4Description: https://datasheet.ciiva.com/17215/aoa0000ce1-17215321.pdf?src-supplier=Avnet+Europe; ComponentLink4URL: https://datasheet.ciiva.com/17215/aoa0000ce1-17215321.pdf?src-supplier=Avnet+Europe; ComponentLink5Description: https://datasheet.ciiva.com/46371/1500035-46371931.pdf?src-supplier=Element14; ComponentLink5URL: https://datasheet.ciiva.com/46371/1500035-46371931.pdf?src-supplier=Element14; ComponentLink6Description: https://datasheet.ciiva.com/28532/1678796-28532156.pdf?src-supplier=Element14; ComponentLink6URL: https://datasheet.ciiva.com/28532/1678796-28532156.pdf?src-supplier=Element14; ComponentLink7Description: https://datasheet.ciiva.com/46386/1500035-46386461.pdf?src-supplier=Element14; ComponentLink7URL: https://datasheet.ciiva.com/46386/1500035-46386461.pdf?src-supplier=Element14; ComponentLink8Description: https://datasheet.ciiva.com/48532/aoa0000ce1-48532159.pdf?src-supplier=Avnet; ComponentLink8URL: https://datasheet.ciiva.com/48532/aoa0000ce1-48532159.pdf?src-supplier=Avnet; Composition: Thick Film; Contact Plating: Tin; Depth: 1.6mm; Features: Automotive AEC-Q200; Height: 0.6mm; Lead Free: Lead Free; Length: 3.2mm; Library Name: DASH1_Schlib1.SchLib; Manufacturer Part Number 1: ERJ-8GEYJ102V; Max Operating Temperature: 155°C; Military Standard: Not; Min Operating Temperature: -55°C; Mount: Surface Mount; Number of Terminations: 2; Package Quantity: 1; Packaging: Tape and Reel; Power Rating: 250mW; Pricing 1: 1=0,10000, 10=0,07400, 100=0,03010, 1000=0,01351, 2500=0,01172 (USD); Radiation Hardening: No; REACH SVHC: Unknown; RoHS: Yes; Stock 1: 1414216; Supplier 1: Digi-Key; Supplier Part Number 1: P1.0KECT-ND; Termination: SMD/SMT; Tolerance: 5%; Voltage Rating (DC): 200V; Width: 0.063inch
R64-R69 = RESISTOR 70R: Res Thick Film 1206(3216) 70 Ohm 5% 1/4W 200ppm/C Molded SMD Punched Carrier T/R; Manufacturer 1: Panasonic; Categoría: Resistencia; Max Power Dissipation: 250mW; Resistance: 1kR; Temperature Coefficient: 200ppm/°C; Voltage Rating: 200V; Case/Package: 1206; Case Code (Imperial): 1206; Case Code (Metric): 3216; Component Kind: Standard; ComponentLink1Description: https://datasheet.ciiva.com/24069/aoa0000ce1-37422-24069653.pdf?src-supplier=Mouser; ComponentLink1URL: https://datasheet.ciiva.com/24069/aoa0000ce1-37422-24069653.pdf?src-supplier=Mouser; ComponentLink2Description: https://datasheet.ciiva.com/30141/4aoa0000ce1-30141014.pdf?src-supplier=Onlinecomponents.com; ComponentLink2URL: https://datasheet.ciiva.com/30141/4aoa0000ce1-30141014.pdf?src-supplier=Onlinecomponents.com; ComponentLink3Description: https://datasheet.ciiva.com/43460/1500035-43460230.pdf?src-supplier=Farnell; ComponentLink3URL: https://datasheet.ciiva.com/43460/1500035-43460230.pdf?src-supplier=Farnell; ComponentLink4Description: https://datasheet.ciiva.com/17215/aoa0000ce1-17215321.pdf?src-supplier=Avnet+Europe; ComponentLink4URL: https://datasheet.ciiva.com/17215/aoa0000ce1-17215321.pdf?src-supplier=Avnet+Europe; ComponentLink5Description: https://datasheet.ciiva.com/46371/1500035-46371931.pdf?src-supplier=Element14; ComponentLink5URL: https://datasheet.ciiva.com/46371/1500035-46371931.pdf?src-supplier=Element14; ComponentLink6Description: https://datasheet.ciiva.com/28532/1678796-28532156.pdf?src-supplier=Element14; ComponentLink6URL: https://datasheet.ciiva.com/28532/1678796-28532156.pdf?src-supplier=Element14; ComponentLink7Description: https://datasheet.ciiva.com/46386/1500035-46386461.pdf?src-supplier=Element14; ComponentLink7URL: https://datasheet.ciiva.com/46386/1500035-46386461.pdf?src-supplier=Element14; ComponentLink8Description: https://datasheet.ciiva.com/48532/aoa0000ce1-48532159.pdf?src-supplier=Avnet; ComponentLink8URL: https://datasheet.ciiva.com/48532/aoa0000ce1-48532159.pdf?src-supplier=Avnet; Composition: Thick Film; Contact Plating: Tin; Depth: 1.6mm; Features: Automotive AEC-Q200; Height: 0.6mm; Lead Free: Lead Free; Length: 3.2mm; Library Name: DASH1_Schlib1.SchLib; Manufacturer Part Number 1: ERJ-8GEYJ102V; Max Operating Temperature: 155°C; Military Standard: Not; Min Operating Temperature: -55°C; Mount: Surface Mount; Number of Terminations: 2; Package Quantity: 1; Packaging: Tape and Reel; Power Rating: 250mW; Pricing 1: 1=0,10000, 10=0,07400, 100=0,03010, 1000=0,01351, 2500=0,01172 (USD); Radiation Hardening: No; REACH SVHC: Unknown; RoHS: Yes; Stock 1: 1414216; Supplier 1: Digi-Key; Supplier Part Number 1: P1.0KECT-ND; Termination: SMD/SMT; Tolerance: 5%; Voltage Rating (DC): 200V; Width: 0.063inch
T4 = TRANSISTOR_BUZZ: TRANS NPN 45V 0.5A SOT23; Manufacturer 1: ON Semiconductor; Collector Base Voltage (VCBO): 50V; Collector Emitter Saturation Voltage: 700mV; Current Rating: 500mA; Element Configuration: Single; Emitter Base Voltage (VEBO): 5V; Frequency: 100MHz; hFE Min: 160; Max Power Dissipation: 300mW; Number of Elements: 1; Case/Package: SOT-23-3; Collector Emitter Breakdown Voltage: 45V; Collector Emitter Voltage (VCEO): 45V; Component Kind: Standard; ComponentLink1Description: https://datasheet.ciiva.com/15138/getdatasheetpartid-38405-15138602.pdf?src-supplier=Verical; ComponentLink1URL: https://datasheet.ciiva.com/15138/getdatasheetpartid-38405-15138602.pdf?src-supplier=Verical; ComponentLink2Description: https://datasheet.ciiva.com/27748/2010413-27748829.pdf?src-supplier=Jameco; ComponentLink2URL: https://datasheet.ciiva.com/27748/2010413-27748829.pdf?src-supplier=Jameco; ComponentLink3Description: https://datasheet.ciiva.com/1195/bc817-16lt1-d-1195763.pdf?src-supplier=Element14; ComponentLink3URL: https://datasheet.ciiva.com/1195/bc817-16lt1-d-1195763.pdf?src-supplier=Element14; ComponentLink4Description: https://datasheet.ciiva.com/976/bc817-16lt1-d-976356.pdf?src-supplier=Digi-Key; ComponentLink4URL: https://datasheet.ciiva.com/976/bc817-16lt1-d-976356.pdf?src-supplier=Digi-Key; ComponentLink5Description: https://datasheet.ciiva.com/1195/bc817-16lt1-d-1195764.pdf?src-supplier=Element14; ComponentLink5URL: https://datasheet.ciiva.com/1195/bc817-16lt1-d-1195764.pdf?src-supplier=Element14; ComponentLink6Description: https://datasheet.ciiva.com/976/bc817-16lt1-d-976357.pdf?src-supplier=Digi-Key; ComponentLink6URL: https://datasheet.ciiva.com/976/bc817-16lt1-d-976357.pdf?src-supplier=Digi-Key; ComponentLink7Description: https://datasheet.ciiva.com/4722/bc817-16lt1-d-104560-4722229.pdf?src-supplier=Mouser; ComponentLink7URL: https://datasheet.ciiva.com/4722/bc817-16lt1-d-104560-4722229.pdf?src-supplier=Mouser; ComponentLink8Description: https://datasheet.ciiva.com/22641/bc817-16lt1-d-22641013.pdf?src-supplier=Farnell; ComponentLink8URL: https://datasheet.ciiva.com/22641/bc817-16lt1-d-22641013.pdf?src-supplier=Farnell; ComponentLink9Description: https://datasheet.ciiva.com/22664/1842041-22664101.pdf?src-supplier=Element14; ComponentLink9URL: https://datasheet.ciiva.com/22664/1842041-22664101.pdf?src-supplier=Element14; ComponentLink10Description: https://datasheet.ciiva.com/976/bc817-16lt1-d-976358.pdf?src-supplier=Digi-Key; ComponentLink10URL: https://datasheet.ciiva.com/976/bc817-16lt1-d-976358.pdf?src-supplier=Digi-Key; ComponentLink11Description: https://datasheet.ciiva.com/25996/bc817-16lt1-d-25996327.pdf?src-supplier=Avnet+Europe; ComponentLink11URL: https://datasheet.ciiva.com/25996/bc817-16lt1-d-25996327.pdf?src-supplier=Avnet+Europe; ComponentLink12Description: https://datasheet.ciiva.com/11197/bc817-16lt1-d-11197514.pdf?src-supplier=Avnet; ComponentLink12URL: https://datasheet.ciiva.com/11197/bc817-16lt1-d-11197514.pdf?src-supplier=Avnet; ComponentLink13Description: https://datasheet.ciiva.com/22664/bc817-16lt1-d-22664204.pdf?src-supplier=Element14; ComponentLink13URL: https://datasheet.ciiva.com/22664/bc817-16lt1-d-22664204.pdf?src-supplier=Element14; ComponentLink14Description: https://datasheet.ciiva.com/1196/bc817-16lt1-d-1196558.pdf?src-supplier=Element14; ComponentLink14URL: https://datasheet.ciiva.com/1196/bc817-16lt1-d-1196558.pdf?src-supplier=Element14; Contact Plating: Tin,Matte; Gain Bandwidth Product: 100MHz; Halogen Free: Halogen Free; Height: 0.94mm; Lead Free: Lead Free; Length: 2.9mm; Library Name: DASH1_Schlib1.SchLib; Manufacturer Part Number 1: BC817-25LT1G; Max Breakdown Voltage: 45V; Max Collector Current: 500mA; Max Frequency: 100MHz; Max Operating Temperature: 150°C; Min Operating Temperature: -55°C; Number of Pins: 3; Package Quantity: 1; Packaging: Tape and Reel; Polarity: NPN; Power Dissipation: 300mW; Pricing 1: 1=0,15000, 10=0,14100, 100=0,05890, 500=0,04626, 1000=0,03213 (USD); Radiation Hardening: No; REACH SVHC: No SVHC; RoHS: Yes; Stock 1: 103204; Supplier 1: Digi-Key; Supplier Part Number 1: BC817-25LT1GOSCT-ND; Transition Frequency: 100MHz; Width: 1.3mm
T5-T7 = MMPQ2222A: TRANS 4NPN 40V 0.5A 16SOIC; Manufacturer 1: ON Semiconductor / Fairchild; Collector Base Voltage (VCBO): 75V; Collector Emitter Saturation Voltage: 1V; Emitter Base Voltage (VEBO): 5V; Frequency: 300MHz; Gain Bandwidth Product: 350MHz; hFE Min: 100; Max Collector Current: 500mA; Max Power Dissipation: 1W; Number of Elements: 4; Polarity: NPN; Case/Package: SOIC; Collector Emitter Breakdown Voltage: 40V; Collector Emitter Voltage (VCEO): 40V; Component Kind: Standard; Height: 1.5mm; Lead Free: Lead Free; Length: 10mm; Library Name: DASH1_Schlib1.SchLib; Manufacturer Part Number 1: MMPQ2222A; Max Breakdown Voltage: 40V; Max Frequency: 300MHz; Max Operating Temperature: 150°C; Min Operating Temperature: -55°C; Mount: Surface Mount; Number of Pins: 16; Package Quantity: 1; Packaging: Tape and Reel; Power Dissipation: 1W; Pricing 1: 1=1,81000, 10=1,62600, 100=1,19650, 500=1,01240, 1000=0,85900 (USD); Radiation Hardening: No; REACH SVHC: No SVHC; RoHS: Yes; Stock 1: 16456; Supplier 1: Digi-Key; Supplier Part Number 1: MMPQ2222ACT-ND; Transition Frequency: 300MHz; Weight: 0.143g; Width: 4mm
  T5: ComponentLink5Description: DATASHEET; ComponentLink5URL: https://datasheet.ciiva.com/968/ffb2222a-968058.pdf?src-supplier=Digi-Key
  T6 T7: ComponentLink1Description: DATASHEET; ComponentLink1URL: https://datasheet.ciiva.com/968/ffb2222a-968058.pdf?src-supplier=Digi-Key
T10-T13 = MMPQ3906: Bipolar Transistors - BJT 200mA 40V PNP; Manufacturer 1: ON Semiconductor / Fairchild; Collector Base Voltage (VCBO): -40V; Collector Emitter Saturation Voltage: -100mV; Current Rating: -200mA; Emitter Base Voltage (VEBO): -5V; Frequency: 200MHz; hFE Min: 75; Max Power Dissipation: 1W; Number of Elements: 4; Case/Package: SOIC; Collector Emitter Breakdown Voltage: 40V; Collector Emitter Voltage (VCEO): 40V; Component Kind: Standard; ComponentLink1Description: DATASHEET; ComponentLink1URL: https://datasheet.ciiva.com/4721/mmpq3906-196132-4721366.pdf?src-supplier=Mouser; Gain Bandwidth Product: 200MHz; Height: 1.5mm; Lead Free: Lead Free; Length: 10mm; Library Name: DASH1_Schlib1.SchLib; Manufacturer Part Number 1: MMPQ3906; Max Breakdown Voltage: 40V; Max Collector Current: 200mA; Max Frequency: 200MHz; Max Operating Temperature: 150°C; Min Operating Temperature: -55°C; Mount: Surface Mount; Number of Pins: 16; Package Quantity: 2500; Packaging: Tape and Reel; Polarity: PNP; Power Dissipation: 1W; Pricing 1: 1=1,73000, 10=1,55400, 100=1,14340, 500=0,96752, 1000=0,82093 (USD); Radiation Hardening: No; REACH SVHC: No SVHC; RoHS: Yes; Stock 1: 819; Supplier 1: Digi-Key; Supplier Part Number 1: MMPQ3906CT-ND; Transition Frequency: 200MHz; Voltage Rating (DC): -40V; Weight: 0.143g; Width: 4mm
T14-T16 = TRANSISTOR: MOSFET N-CH 35V 3A CPH3; Manufacturer 1: ON Semiconductor; Drain to Source Resistance: 108mR; Element Configuration: Single; Fall Time: 5.7ns; Gate to Source Voltage (Vgs): 20V; Input Capacitance: 186pF; Max Power Dissipation: 1W; Rds On Max: 104mR; Rise Time: 4.7ns; Turn-Off Delay Time: 15ns; Turn-On Delay Time: 4.2ns; Case/Package: SC; Category: Transistor; Component Kind: Standard; ComponentLink1Description: https://datasheet.ciiva.com/4444/cph3455-d-91653-4444616.pdf?src-supplier=Mouser; ComponentLink1URL: https://datasheet.ciiva.com/4444/cph3455-d-91653-4444616.pdf?src-supplier=Mouser; ComponentLink2Description: https://datasheet.ciiva.com/11164/cph3455-d-11164889.pdf?src-supplier=Avnet; ComponentLink2URL: https://datasheet.ciiva.com/11164/cph3455-d-11164889.pdf?src-supplier=Avnet; ComponentLink3Description: https://datasheet.ciiva.com/947/cph3455-d-947201.pdf?src-supplier=Digi-Key; ComponentLink3URL: https://datasheet.ciiva.com/947/cph3455-d-947201.pdf?src-supplier=Digi-Key; Continuous Drain Current (ID): 3A; Drain to Source Breakdown Voltage: 35V; Drain to Source Voltage (Vdss): 35V; Halogen Free: Halogen Free; Height: 0.9mm; Lead Free: Lead Free; Length: 2.9mm; Library Name: DASH1_Schlib1.SchLib; Manufacturer Part Number 1: CPH3455-TL-H; Max Operating Temperature: 150°C; Min Operating Temperature: -55°C; Number of Pins: 3; Package Quantity: 3000; Packaging: Tape and Reel; Power Dissipation: 1W; Pricing 1: 50=0,09600, 100=0,16000, 250=0,15300, 500=0,14600, 1000=0,11600 (EUR); RoHS: Yes; Stock 1: 2250; Supplier 1: RSComponents; Supplier Part Number 1: 8009989; Width: 1.6mm
U1a U4a = SN74HC164D: IC, SHIFT REGISTER, SMD, 74HC164; Manufacturer 1: Texas Instruments; Direction: Unidirectional; Max Supply Voltage: 6V; Min Supply Voltage: 2V; Number of Bits: 8; Number of Circuits: 1; Number of Input Lines: 2; Operating Supply Voltage: 5V; Propagation Delay: 30ns; Turn-On Delay Time: 255ns; Case/Package: SOIC; Component Kind: Standard; ComponentLink1Description: DATASHEET; ComponentLink1URL: https://datasheet.ciiva.com/15093/getdatasheetpartid-81705-15093317.pdf?src-supplier=Verical; Height: 1.58mm; Lead Free: Lead Free; Length: 8.65mm; Library Name: DASH1_Schlib1.SchLib; Logic Function: Shift Register; Manufacturer Part Number 1: SN74HC164D; Max Operating Temperature: 125°C; Min Operating Temperature: -40°C; Mount: Surface Mount; Number of Bits per Element: 8; Number of Elements: 1; Number of Output Lines: 8; Number of Pins: 14; Package Quantity: 50; Packaging: Rail/Tube; Pricing 1: 1=0,39000, 10=0,33800, 25=0,31520, 100=0,25200, 250=0,23400, 500=0,19800, 1000=0,15300, 2500=0,13950, 5000=0,13050 (USD); Radiation Hardening: No; REACH SVHC: No SVHC; RoHS: Yes; Stock 1: 1795; Supplier 1: Digi-Key; Supplier Part Number 1: 296-8247-5-ND; Weight: 0.004318oz; Width: 3.91mm
U2a U3a = SN74LS47D: TEXAS INSTRUMENTS         SN74LS47D            LOGIC, BCD TO 7-SEG DECODER, 16SOIC; Bandwidth: 35MHz; High Level Output Current: -50uA; Logic Function: Demultiplexer,Decoder; Low Level Output Current: 3.2mA; Max Input Voltage: 5.25V; Min Input Voltage: 4.75V; Number of Bits: 7; Number of Channels: 1; Number of Input Lines: 4; Operating Supply Current: 7mA; Operating Supply Voltage: 5V; Polarity: Inverting; Propagation Delay: 100ns; Component Kind: Standard; ComponentLink1Description: DATASHEET; ComponentLink1URL: https://datasheet.ciiva.com/15092/getdatasheetpartid-251224-15092377.pdf?src-supplier=Verical; Contact Plating: Gold; Height: 1.5mm; Lead Free: Lead Free; Length: 10mm; Library Name: DASH1_Schlib1.SchLib; Manufacturer 1: Texas Instruments; Manufacturer Part Number 1: SN74LS47D; Max Operating Temperature: 70°C; Max Supply Voltage: 5.25V; Min Operating Temperature: 0°C; Min Supply Voltage: 4.75V; Number of Circuits: 1; Number of Elements: 1; Number of Inputs: 4; Number of Output Lines: 7; Number of Outputs: 7; Number of Pins: 16; Package Quantity: 40; Packaging: Rail/Tube; Pricing 1: 1=1,85000, 10=1,66200, 25=1,56760, 100=1,33550, 250=1,25400, 500=1,09726, 1000=0,90915, 2500=0,84645, 5000=0,81510 (USD); Quiescent Current: 7mA; Radiation Hardening: No; REACH SVHC: No SVHC; RoHS: Yes; Stock 1: 475; Supplier 1: Digi-Key; Supplier Part Number 1: 296-3711-5-ND; Turn-On Delay Time: 100ns; Weight: 0.004998oz; Width: 4mm
